# Altium schematic sheet Arduino.SchDoc(Arduino)
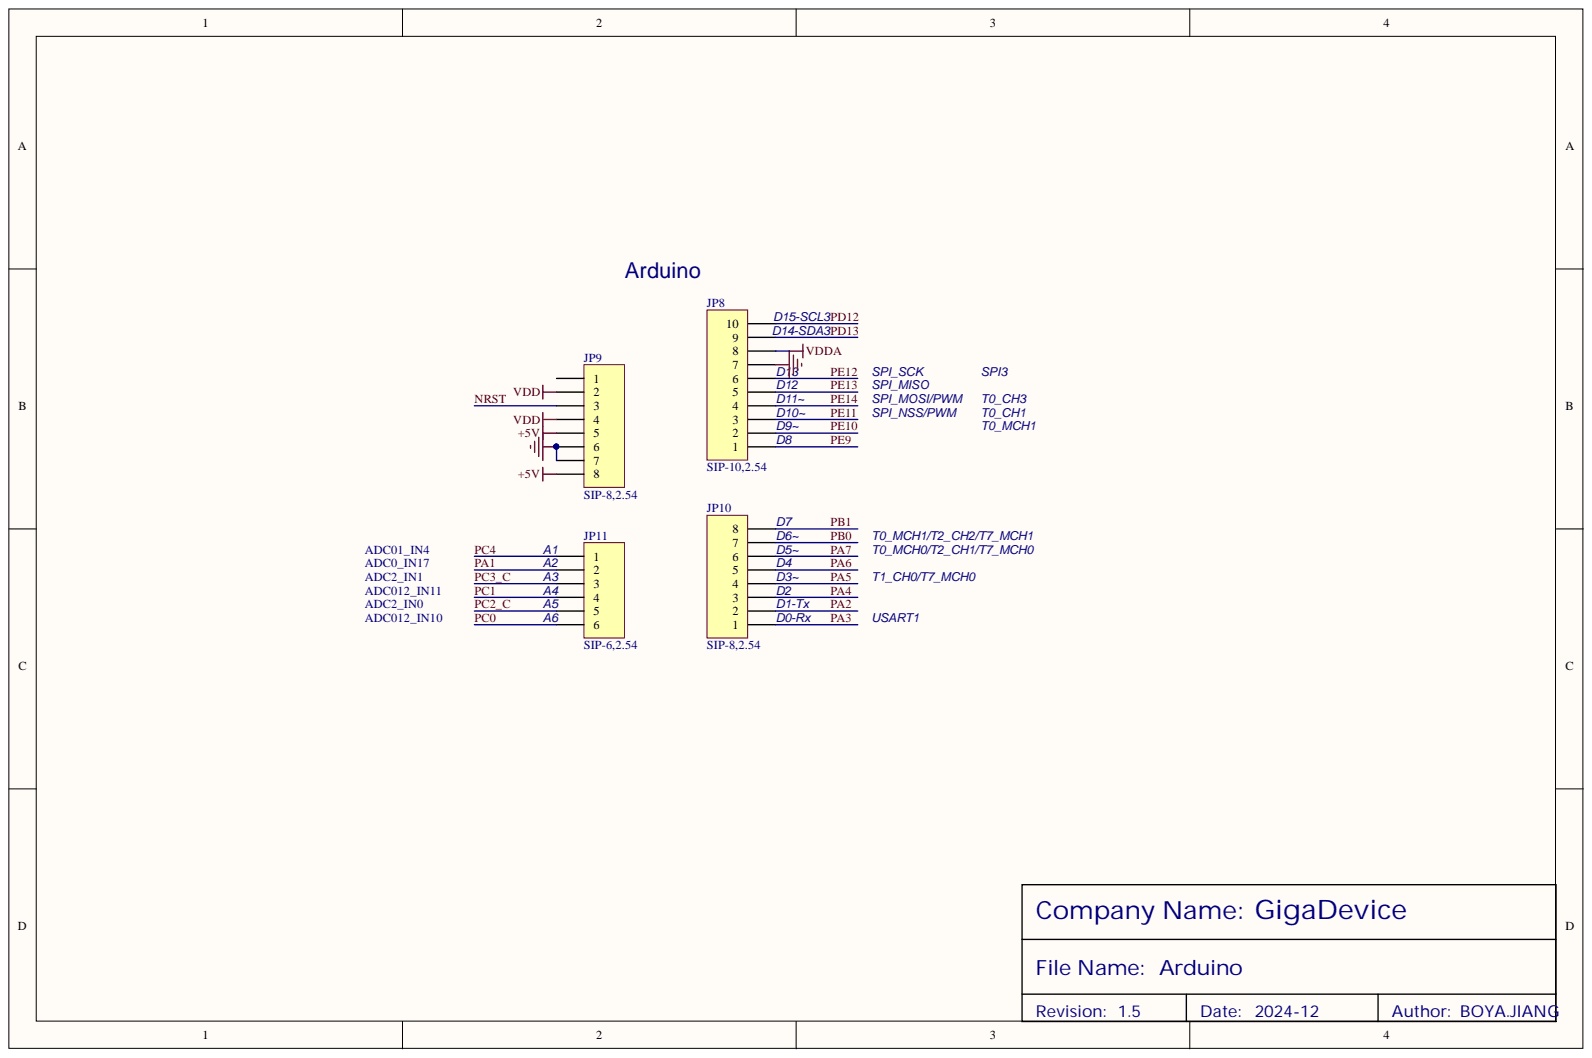
[diagram p0: Arduino.SchDoc]

## Parts
JP8  SIP-10,2.54  [SIP-10]
JP9  SIP-8,2.54  [SIP-8]
JP10  SIP-8,2.54  [SIP-8]
JP11  SIP-6,2.54  [SIP-6]

## Nets
+5V: JP9.5 JP9.8
GND: JP8.7 JP9.6 JP9.7
VDD: JP9.2 JP9.4
VDDA: JP8.8
NRST: JP9.3
PA1: JP11.2
PA2: JP10.2
PA3: JP10.1
PA4: JP10.3
PA5: JP10.4
PA6: JP10.5
PA7: JP10.6
PB0: JP10.7
PB1: JP10.8
PC0: JP11.6
PC1: JP11.4
PC2_C: JP11.5
PC3_C: JP11.3
PC4: JP11.1
PD12: JP8.10
PD13: JP8.9
PE9: JP8.1
PE10: JP8.2
PE11: JP8.3
PE12: JP8.6
PE13: JP8.5
PE14: JP8.4

Not connected: JP9 1

## Library data of the parts
JP8 = HEADER 10: 直插单排排母; Component Kind: Standard; Library Name: KLTC-按键及接插件.SchLib
JP9 JP10 = HEADER 8: 直插单排排母; Component Kind: Standard; Library Name: KLTC-按键及接插件.SchLib
JP11 = HEADER 6: 直插单排排母; Component Kind: Standard; Library Name: KLTC-按键及接插件.SchLib
